# Altium schematic sheet Interface.SchDoc(Interface)
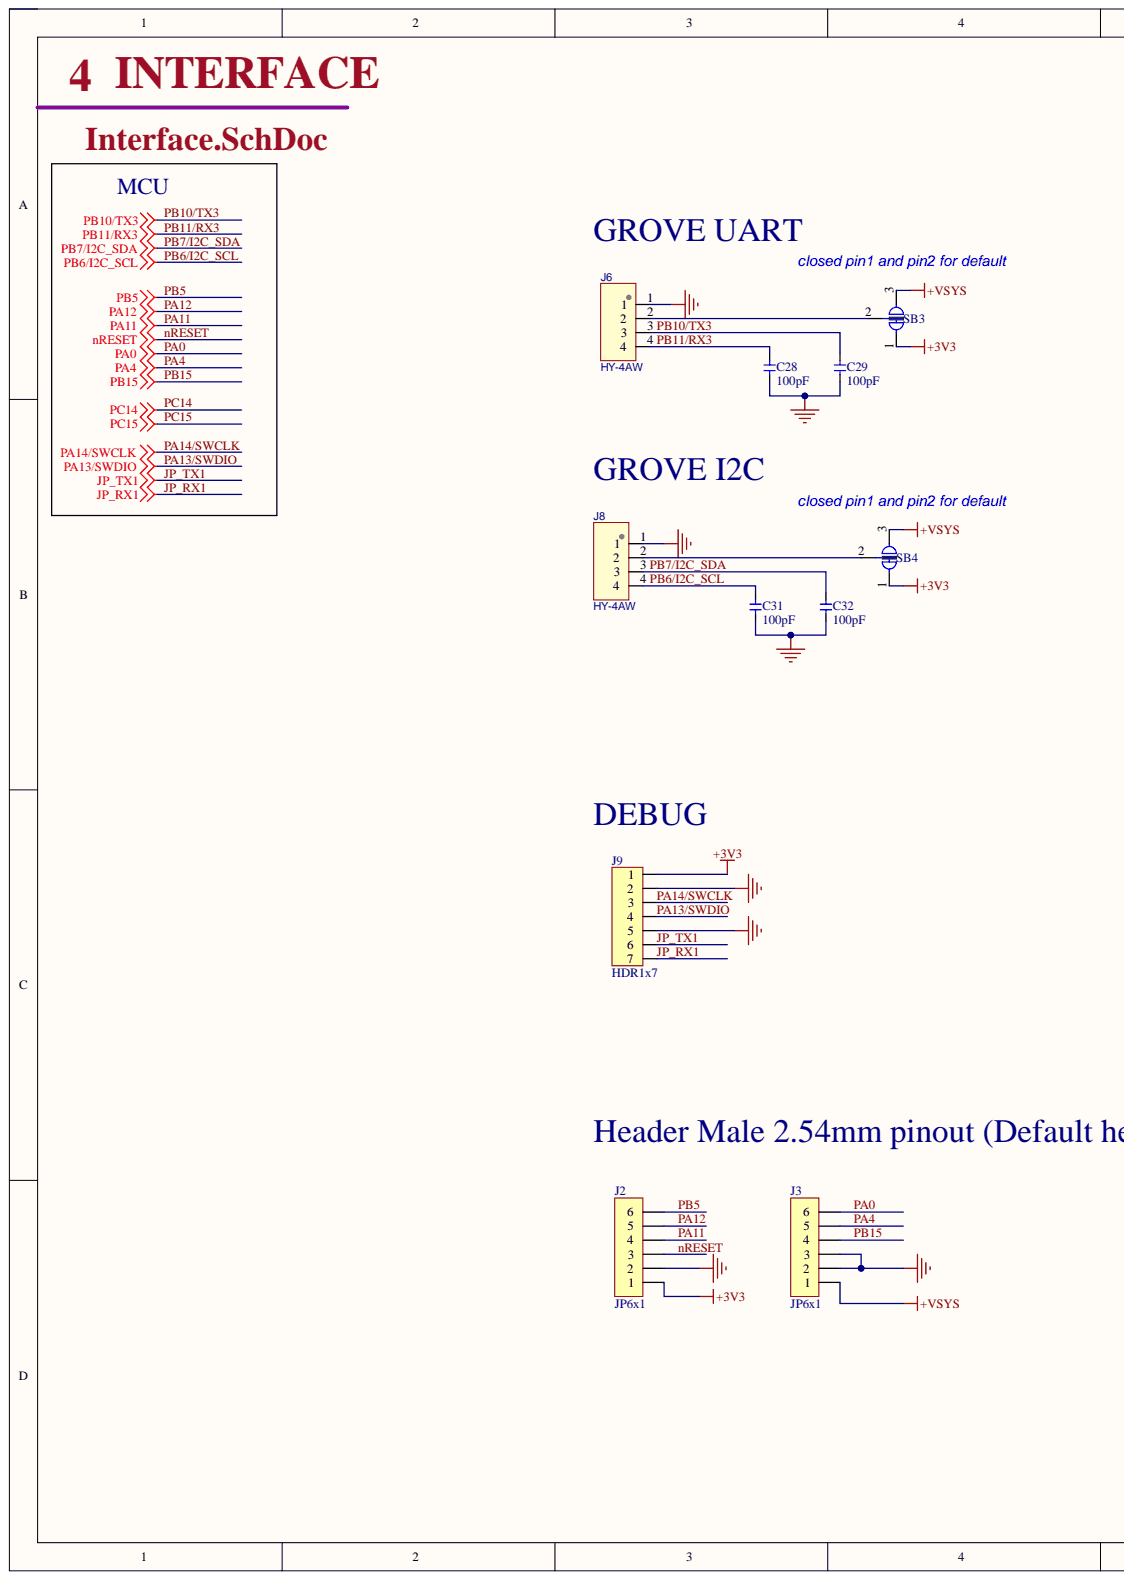
[diagram p0: Interface.SchDoc, left]
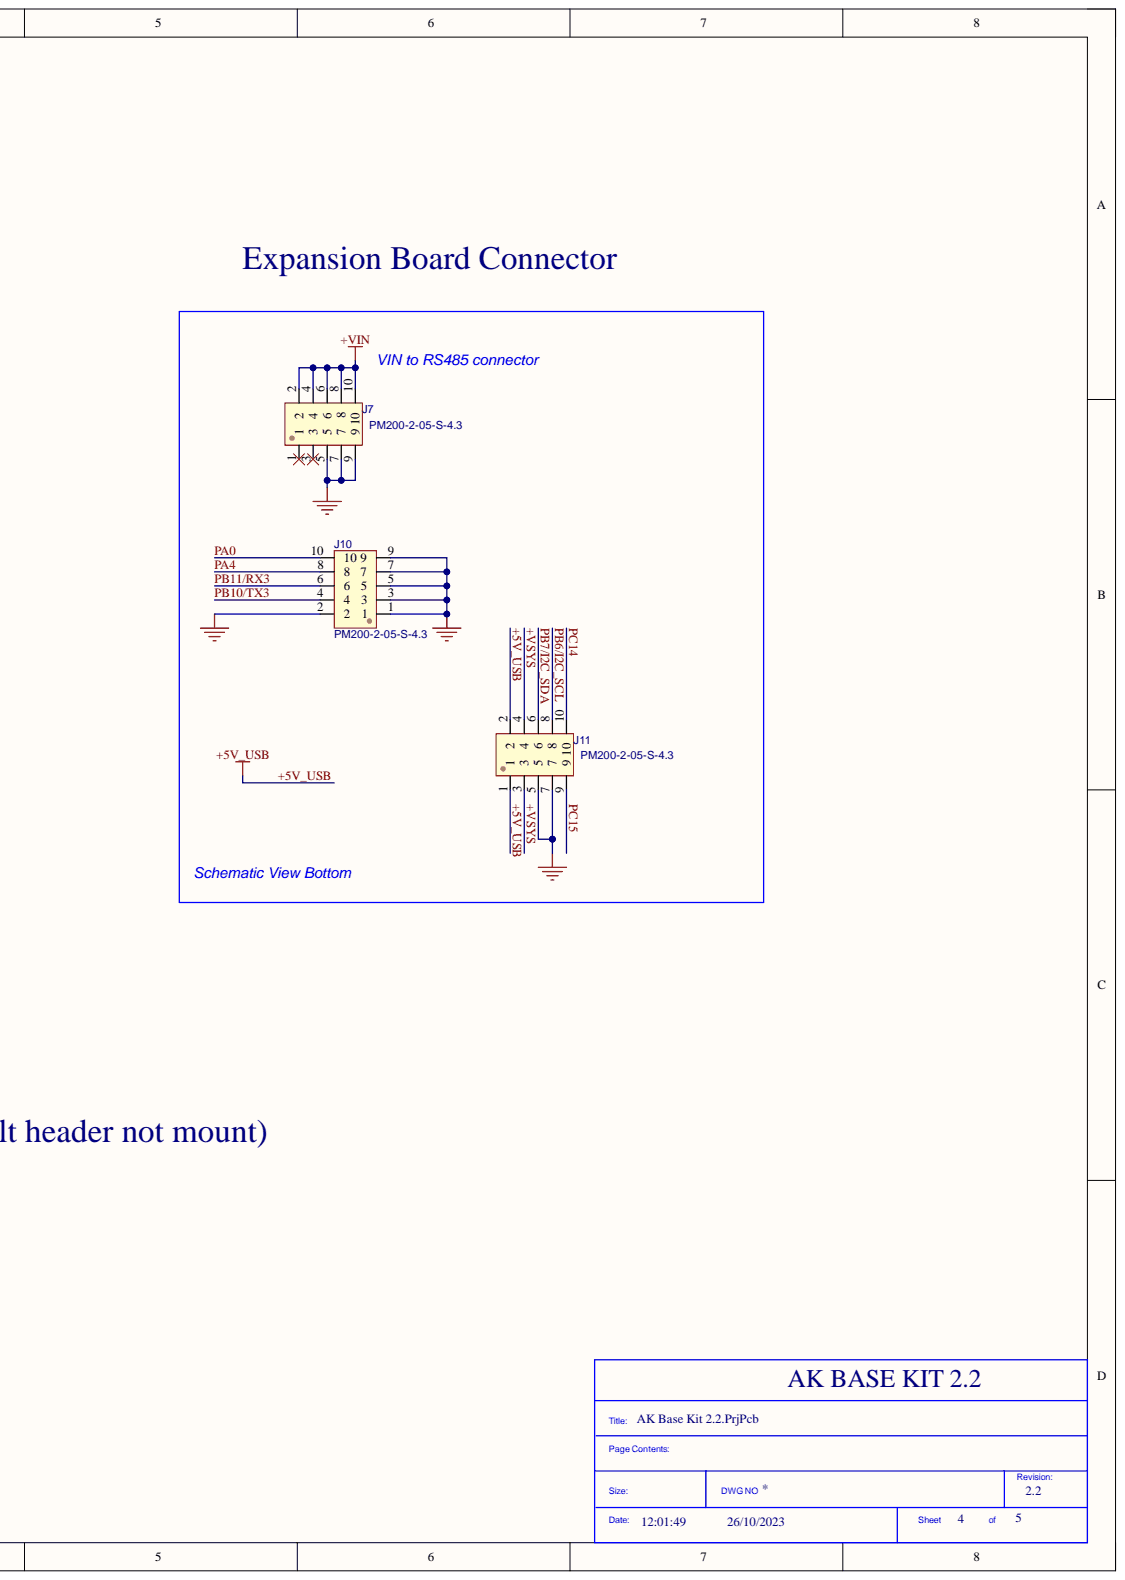
[diagram p1: Interface.SchDoc, right]

## Parts
C28  100pF  [C_0402]
C29  100pF  [C_0402]
C31  100pF  [C_0402]
C32  100pF  [C_0402]
J2  JP6x1  [JP6-D1T]  (no BOM)
J3  JP6x1  [JP6-D1T]  (no BOM)
J6  HY-4AW  [CONN-TH_4P-P2.00_HY-4AW]
J7  PM200-2-05-S-4.3  [HDR-SMD_10P-P2.00-V-F-R2-C5-LS6.5]
J8  HY-4AW  [CONN-TH_4P-P2.00_HY-4AW]
J9  HDR1x7  [HDR1X7H]
J10  PM200-2-05-S-4.3  [HDR-SMD_10P-P2.00-V-F-R2-C5-LS6.5]
J11  PM200-2-05-S-4.3  [HDR-SMD_10P-P2.00-V-F-R2-C5-LS6.5]
SB3  SOLDERJUMPER_2WAY  [SOLDERJUMPER_2WAY]  (value not drawn)  (no BOM)
SB4  SOLDERJUMPER_2WAY  [SOLDERJUMPER_2WAY]  (value not drawn)  (no BOM)

## Nets
+3V3: J2.1 J9.1 SB3.1 SB4.1
+VIN: J7.2 J7.4 J7.6 J7.8 J7.10
GND: C28.1 C29.2 C31.1 C32.1 J2.2 J3.2 J3.3 J6.1 J7.5 J7.7 J7.9 J8.1 J9.2 J9.5 J10.1 J10.2 J10.3 J10.5 J10.7 J10.9 J11.5 J11.7
+5V_USB: J11.1 J11.2
+VSYS: J3.1 J11.3 J11.4 SB3.3 SB4.3
JP_RX1: J9.7
JP_TX1: J9.6
nRESET: J2.3
PA0: J3.6 J10.10
PA4: J3.5 J10.8
PA11: J2.4
PA12: J2.5
PA13/SWDIO: J9.4
PA14/SWCLK: J9.3
PB5: J2.6
PB6/I2C_SCL: C31.2 J8.4 J11.8
PB7/I2C_SDA: C32.2 J8.3 J11.6
PB10/TX3: C29.1 J6.3 J10.4
PB11/RX3: C28.2 J6.4 J10.6
PB15: J3.4
PC14: J11.10
PC15: J11.9
NetJ6_2: J6.2 SB3.2
NetJ8_2: J8.2 SB4.2

Not connected: J7 1 3

## Library data of the parts
C28 C29 C31 C32 = 0402CG101J500NT: 100pF 50V C0G ±5% 0402 Multilayer Ceramic Capacitors MLCC - SMD/SMT ROHS; Manufacturer: FH(Guangdong Fenghua Advanced Tech); Assembly Type: SMT Assembly; Component Kind: Standard; ComponentLink1Description: Digikey Link; ComponentLink2Description: LCSC Link; ComponentLink2URL: https://jlcpcb.com/parts/componentSearch?searchTxt=10k%200603; LCSC Part Number: C1546; Library Name: Dev Board STM OLED 2.0.SCHLIB; Manufacturer Part Number: 0402CG101J500NT; Package: 0402
J2 J3 = JP 6X1 2.54: WR-PHD 2.54 mm Dual Socket Header; Manufacturer: W¨¹rth Elektronik eiSos GmbH; partNo: 61301621821; ComponentLink1 Description: Manufacturer; ComponentLink2 Description: Datasheet; NoPins: 16; ComponentLink1URL: http://www.we-online.com; ComponentLink2URL: http://katalog.we-online.de/em/datasheet/6130xx21821.pdf; Created Date: 29 March 2013; Library Name: Dev Board STM OLED 2.0.SCHLIB; Created by: Karrer Zheng
J6 J8 = HY-4AW: HY-4AW 1x4P 1 2mm 4 Push-Pull,P=2mm Wire To Board / Wire To Wire Connector ROHS; Manufacturer: BOOMELE; Pakage: 弯插,P=2mm; Assembly Type: Hand Soldering; Component Kind: Standard; LCSC Part Number: C146068; Library Name: Dev Board STM OLED 2.0.SchLib; Manufacturer Part Number: HY-4AW
J7 J10 J11 = PM200-2-05-S-4.3: SMD,P=2mm Female Headers ROHS; Manufacturer: HCTL(华灿天禄); Assembly Type: SMT Assembly; Component Kind: Standard; LCSC Part Number: C3975169; Library Name: Dev Board STM OLED 2.1.SCHLIB; Manufacturer Part Number: PM200-2-05-S-4.3; Package: SMD, P=2mm
J9 = Header 1x7: Header IDC, 1x8 Pin horizontal 2.54mm Pitch (Non-Boxed, Male); Manufacturer: China; Manufacturer Part Number: HDR1x7 Horizonal; ComponentLink1 Description: Manufacturer; ComponentLink2 Description: Datasheet; Assembly Type: Hand Soldering; Component Kind: Standard; ComponentLink1URL: http://www.we-online.com; ComponentLink2URL: http://katalog.we-online.de/em/datasheet/6130xx143121.pdf; Library Name: AK Base Kit 2.2.SCHLIB; Package: P=2.54
SB3 SB4 = SOLDERJUMPER_2WAY: 2-Way Solder Jumper; GateName_1: G$1; DeviceSetName: SOLDERJUMPER_2WAY; Library Name: AK Base Kit 2.2.SCHLIB; LibraryName: microbuilder; SymbolName_1: SOLDERJUMPER_2WAY
